# Altium schematic sheet TOF-LITEON-1A.SchDoc
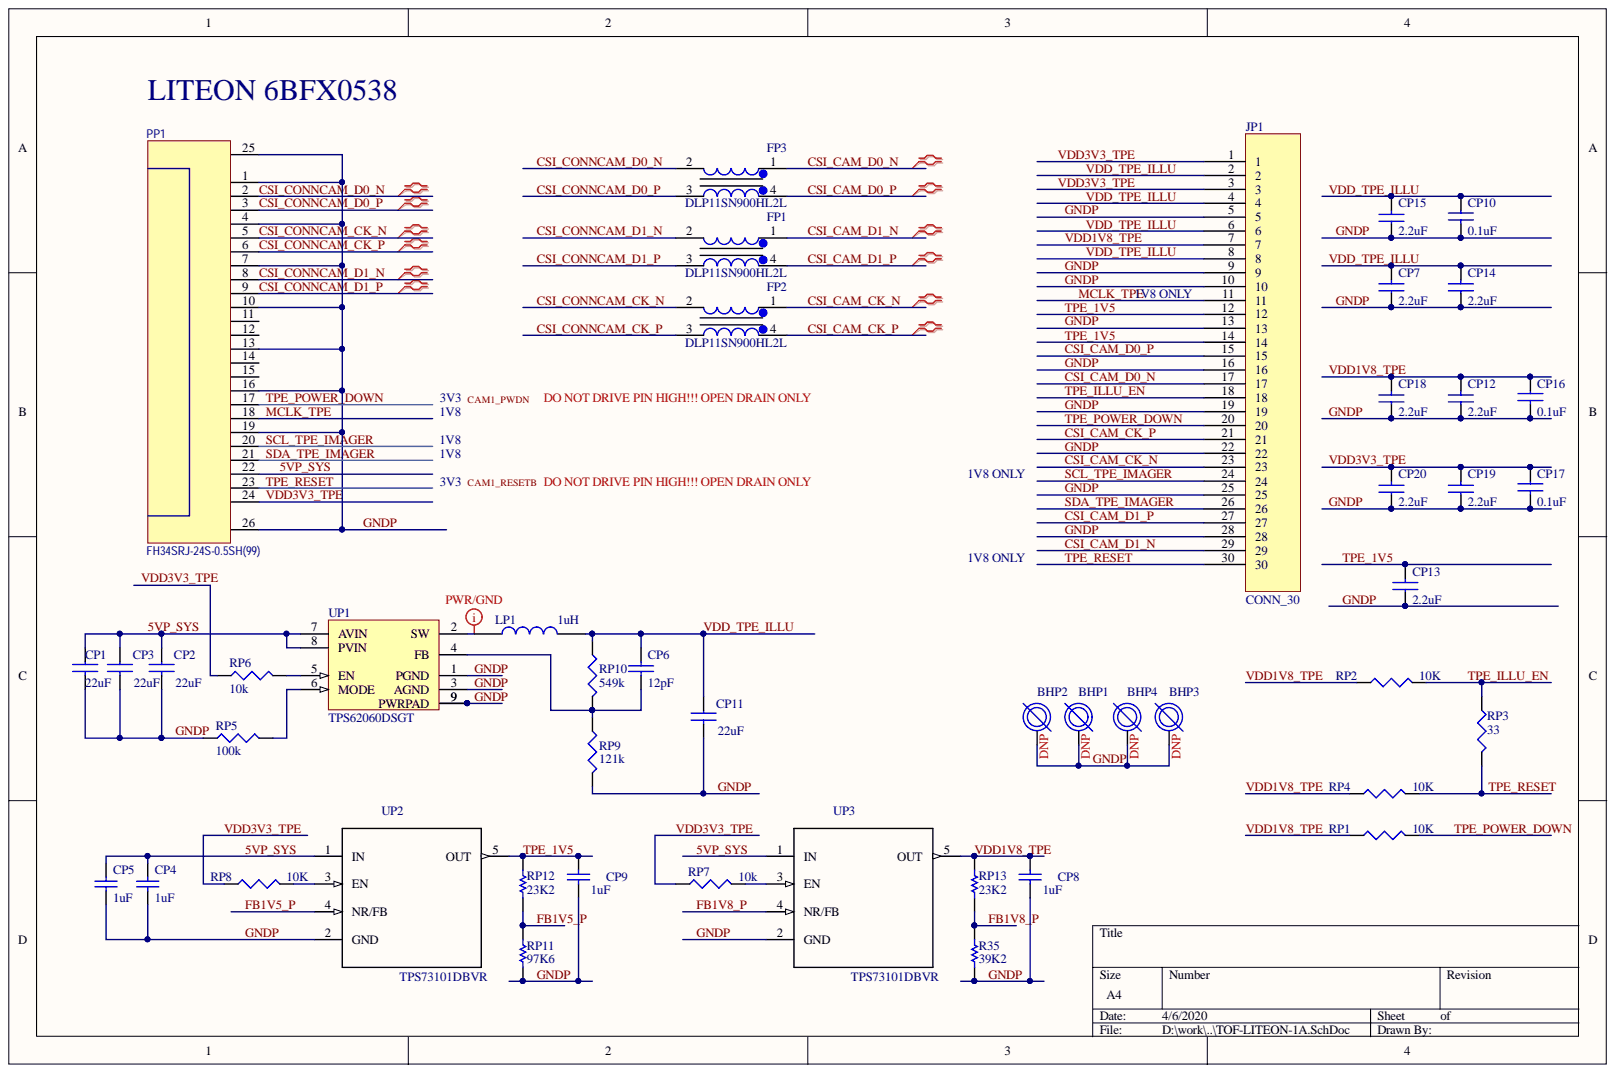
[diagram p0: TOF-LITEON-1A.SchDoc]

## Parts
BHP1  Notes: DNP  [HOLE120-80]  (hidden comment: TH-0.6-3.0-M1.4)
BHP2  Notes: DNP  [HOLE120-80]  (hidden comment: TH-0.6-3.0-M1.4)
BHP3  Notes: DNP  [HOLE120-80]  (hidden comment: TH-0.6-3.0-M1.4)
BHP4  Notes: DNP  [HOLE120-80]  (hidden comment: TH-0.6-3.0-M1.4)
CP1  22uF  [CAPC1608X95N]
CP2  22uF  [CAPC1608X95N]
CP3  22uF  [CAPC1608X95N]
CP4  1uF  [C0402]
CP5  1uF  [C0402]
CP6  12pF  [CAPC1005X60N]
CP7  2.2uF  [CAPC1608X95N]
CP8  1uF  [C0402]
CP9  1uF  [C0402]
CP10  0.1uF  [CAPC1005X60N]
CP11  22uF  [CAPC1608X95N]
CP12  2.2uF  [CAPC1608X95N]
CP13  2.2uF  [CAPC1608X95N]
CP14  2.2uF  [CAPC1608X95N]
CP15  2.2uF  [CAPC1608X95N]
CP16  0.1uF  [CAPC1005X60N]
CP17  0.1uF  [CAPC1005X60N]
CP18  2.2uF  [CAPC1608X95N]
CP19  2.2uF  [CAPC1608X95N]
CP20  2.2uF  [CAPC1608X95N]
FP1  DLP11SN900HL2L  [FIL_DLP11SN900HL2L]
FP2  DLP11SN900HL2L  [FIL_DLP11SN900HL2L]
FP3  DLP11SN900HL2L  [FIL_DLP11SN900HL2L]
JP1  CONN_30  [AXE530127]
LP1  1uH  [INDM4040X180N]
PP1  FH34SRJ-24S-0.5SH(99)  [FPC24-0_5-14_18x4_71MM]
R35  39K2  [R0402]
RP1  10K  [R0402]
RP2  10K  [R0402]
RP3  33  [R0402]
RP4  10K  [R0402]
RP5  100k  [RESC1005N]
RP6  10k  [RESC1005N]
RP7  10k  [RESC1005N]
RP8  10K  [R0402]
RP9  121k  [RESC1005N]
RP10  549k  [RESC1005N]
RP11  97K6  [R0402]
RP12  23K2  [R0402]
RP13  23K2  [R0402]
UP1  TPS62060DSGT  [SON50P200X200X80-9N]
UP2  TPS73101DBVR  [*SOT95P280X145-5N]
UP3  TPS73101DBVR  [*SOT95P280X145-5N]

## Nets
5VP_SYS: CP1.1 CP2.1 CP3.1 CP4.2 CP5.2 PP1.22 UP1.7(AVIN) UP1.8(PVIN) UP2.1(IN) UP3.1(IN)
CSI_CAM_CK_N: FP2.1 JP1.23
CSI_CAM_CK_P: FP2.4 JP1.21
CSI_CAM_D0_N: FP3.1 JP1.17
CSI_CAM_D0_P: FP3.4 JP1.15
CSI_CAM_D1_N: FP1.1 JP1.29
CSI_CAM_D1_P: FP1.4 JP1.27
CSI_CONNCAM_CK_N: FP2.2 PP1.5
CSI_CONNCAM_CK_P: FP2.3 PP1.6
CSI_CONNCAM_D0_N: FP3.2 PP1.2
CSI_CONNCAM_D0_P: FP3.3 PP1.3
CSI_CONNCAM_D1_N: FP1.2 PP1.8
CSI_CONNCAM_D1_P: FP1.3 PP1.9
FB1V5_P: RP11.2 RP12.1 UP2.4(NR/FB)
FB1V8_P: R35.2 RP13.1 UP3.4(NR/FB)
GNDP: BHP1.1 BHP2.1 BHP3.1 BHP4.1 CP1.2 CP2.2 CP3.2 CP4.1 CP5.1 CP7.1 CP8.1 CP9.1 CP10.2 CP11.2 CP12.1 CP13.1 CP14.1 CP15.1 CP16.2 CP17.2 CP18.1 CP19.1 CP20.1 JP1.5 JP1.9 JP1.10 JP1.13 JP1.16 JP1.19 JP1.22 JP1.25 JP1.28 PP1.1 PP1.4 PP1.7 PP1.10 PP1.13 PP1.16 PP1.19 PP1.25 PP1.26 R35.1 RP5.1 RP9.2 RP11.1 UP1.1(PGND) UP1.3(AGND) UP1.9(PWRPAD) UP2.2(GND) UP3.2(GND)
MCLK_TPE: JP1.11 PP1.18
SCL_TPE_IMAGER: JP1.24 PP1.20
SDA_TPE_IMAGER: JP1.26 PP1.21
TPE_1V5: CP9.2 CP13.2 JP1.12 JP1.14 RP12.2 UP2.5(OUT)
TPE_ILLU_EN: JP1.18 RP2.2 RP3.2
TPE_POWER_DOWN: JP1.20 PP1.17 RP1.2
TPE_RESET: JP1.30 PP1.23 RP3.1 RP4.2
VDD1V8_TPE: CP8.2 CP12.2 CP16.1 CP18.2 JP1.7 RP1.1 RP2.1 RP4.1 RP13.2 UP3.5(OUT)
VDD3V3_TPE: CP17.1 CP19.2 CP20.2 JP1.1 JP1.3 PP1.24 RP6.2 RP7.2 RP8.1
VDD_TPE_ILLU: CP6.1 CP7.2 CP10.1 CP11.1 CP14.2 CP15.2 JP1.2 JP1.4 JP1.6 JP1.8 LP1.2 RP10.1
NetCP6_2: CP6.2 RP9.1 RP10.2 UP1.4(FB)
NetLP1_1: LP1.1 UP1.2(SW)
NetRP5_2: RP5.2 UP1.6(MODE)
NetRP6_1: RP6.1 UP1.5(EN)
NetRP7_1: RP7.1 UP3.3(EN)
NetRP8_2: RP8.2 UP2.3(EN)
CAM1_PWDN:
CAM1_RESETB:

Not connected: PP1 11 12 14 15

## Library data of the parts
BHP1-BHP4 = MOUNT_HOLE: TH-0.6-3.0-M1.4 / https://www.mac8japan.com/products/458; Manufacturer: Mac8; Component Kind: Standard; ManType: TH-0.6-3.0-M1.4
CP1-CP3 CP11 = CAP NP: 22uF +-20% 6.3V Ceramic Capacitor X5R 0603; Manufacturer: Samsung; Emcraft #: E-CAP-049; ManType: CL10A226MQ8NRNC; Component Kind: Standard; Digikey: 1276-1193-1-ND; Package: 0603; Part #: E-CAP-049; Price 100: 0.0850; Price 1000: 0.05250
CP4 CP5 CP8 CP9 = Cap_1uF_X5R_10%_6V3_0402: CAP CER 1UF 10V X5R 0402; Manufacturer: Würth Elektronik; ManType: 885012105012; Component Kind: Standard; Digikey: 732-7489-6-ND; Library Name: MCD_Temp_LBE.SchLib
CP6 = CAP: CAP CER 12PF 50V 5% NPO 0402; Manufacturer: Yageo; Emcraft #: E-CAP-024; ManType: CC0402JRNPO9BN120; Component Kind: Standard; Digikey: 311-1016-1-ND; Library Name: Main.SCHLIB; Package: 0402; Part #: E-CAP-024; Price 100: 0.0023
CP7 CP12-CP15 CP18-CP20 = CAP NP: CAP CER 2.2UF 10V X5R 0402; Manufacturer: Würth Elektronik; ManType: 885012105013; Component Kind: Standard; Digikey: 732-7490-6-ND
CP10 CP16 CP17 = CAP NP: CAP CER 0.1UF 25V X5R 0402; Manufacturer: Würth Elektronik; ManType: 885012105018; Component Kind: Standard; Digikey: 732-7495-1-ND
FP1-FP3 = DLP11SN900HL2L: MURATA# DLP11SN900HL2L, D LP Series 90 Ohm Impedanc e 20 % 150 mA DCR 1.5 Ohm SMT Common Mode Choke; Manufacturer: Murata; Component Kind: Standard; DigiKey: 490-5143-1-ND; Library Name: DLP11SN900HL2L.SchLib; ManType: DLP11SN900HL2L
JP1 = CONN_30: CONN SOCKET .4MM 30 POS SMD; Manufacturer: Panasonic Electric Works; Component Kind: Standard; DigiKey: 255-5287-1-ND; ManType: AXE530127; Library Name: IMX8M-TOF.SchLib
LP1 = INDUCTOR: FIXED IND 1UH 2A 25 MOHM SMD; Manufacturer: Laird-Signal Integrity Products; Emcraft #: E-IDT-025; ManType: TYS40181R0N-10; Component Kind: Standard; Digikey: 240-2671-2-ND; Library Name: Main.SCHLIB; Package: Non standard; Part #: E-IDT-025
PP1 = CONN_ACES_50696-0240MV02: CONN FPC/FFC 24POS .5MM; Manufacturer: Hirose Electric Co Ltd; Component Kind: Standard; DigiKey: H124725CT-ND; ManType: FH34SRJ-24S-0.5SH(99); Library Name: SpacePark.SchLib
R35 = Res_10K_1%_0402: RES SMD 39.2K OHM 1% 1/16W 0402; Manufacturer: STACKPOLE; Part #: E-RES-062; ManType: RMCF0402FT39K2; Component Kind: Standard; Package: 0402; Library Name: MCD_Temp_LBE.SchLib
RP1 RP2 RP4 RP8 = R_SM: RES 10K OHM 1/16W 5% 0402; Manufacturer: Stackpole Electronics Inc; ManType: RMCF0402JT10K0; Component Kind: Standard; DigiKey: RMCF0402JT10K0CT-ND
RP3 = R_SH: RES 33 OHM 1/16W 5% 0402; Manufacturer: Stackpole Electronics Inc; ManType: RMCF0402JT33R0; Component Kind: Standard; Digikey: RMCF0402JT33R0CT-ND; Library Name: main.SchLib
RP5 = R_SH: RES SMD 100K OHM 5% 1/16W 0402; Manufacturer: Vishay Dale; Emcraft #: E-RES-031; ManType: RMCF0402JT100K; Component Kind: Standard; Digikey: RMCF0402JT100KCT-ND; Package: 0402; Part #: E-RES-031; Price: 0.00378
RP6 RP7 = R_SM: RES 10K OHM 1/16W 5% 0402; Manufacturer: Stackpole Electronics Inc; Part #: E-RES-003; ManType: RMCF0402JT10K0; Component Kind: Standard; Digikey: RMCF0402JT10K0CT-ND; Package: 0402; Price 100: 0.00700
RP9 = R_SH: RES 121K OHM 1/16W 1% 0402; Manufacturer: Samsung; Part #: E-RES-036; ManType: RC1005F1213CS; Component Kind: Standard; Digikey: 1276-4209-6-ND; Package: 0402; Price: 0.0015
RP10 = R_SH: RES 549K OHM 1/16W 1% 0402; Manufacturer: Samsung; Part #: E-RES-035; ManType: RC1005F5493CS; Component Kind: Standard; Digikey: 1276-3472-6-ND; Package: 0402; Price: 0.002
RP11 = Res_10K_1%_0402: RES SMD 97.6K OHM 1% 1/16W 0402; Manufacturer: STACKPOLE; Part #: E-RES-061; ManType: RMCF0402FT97K6; Component Kind: Standard; Package: 0402; Library Name: MCD_Temp_LBE.SchLib
RP12 RP13 = Res_10K_1%_0402: RES SMD 23.2K OHM 1% 1/16W 0402; Manufacturer: YAGEO; Part #: E-RES-075; ManType: RC0402FR-0723K2L; Component Kind: Standard; Package: 0402; Library Name: MCD_Temp_LBE.SchLib
UP1 = TPS62060_1: IC REG BUCK ADJ 1.6A 8WSON; Manufacturer: Texas Instruments; Component Kind: Standard; DigiKey: 296-27229-6-ND; Library Name: main.SchLib; ManType: TPS62060DSG
UP2 UP3 = TPS73101DBVR: IC REG LIN POS ADJ 150MA SOT23-5; Manufacturer: Texas Instruments; Component Kind: Standard; DigiKey: 296-27058-1-ND; Library Name: TPS73101DBVR.SchLib; ManType: TPS73101DBVR
